# Altium schematic sheet LCD_SD.SchDoc(LCD_SD)
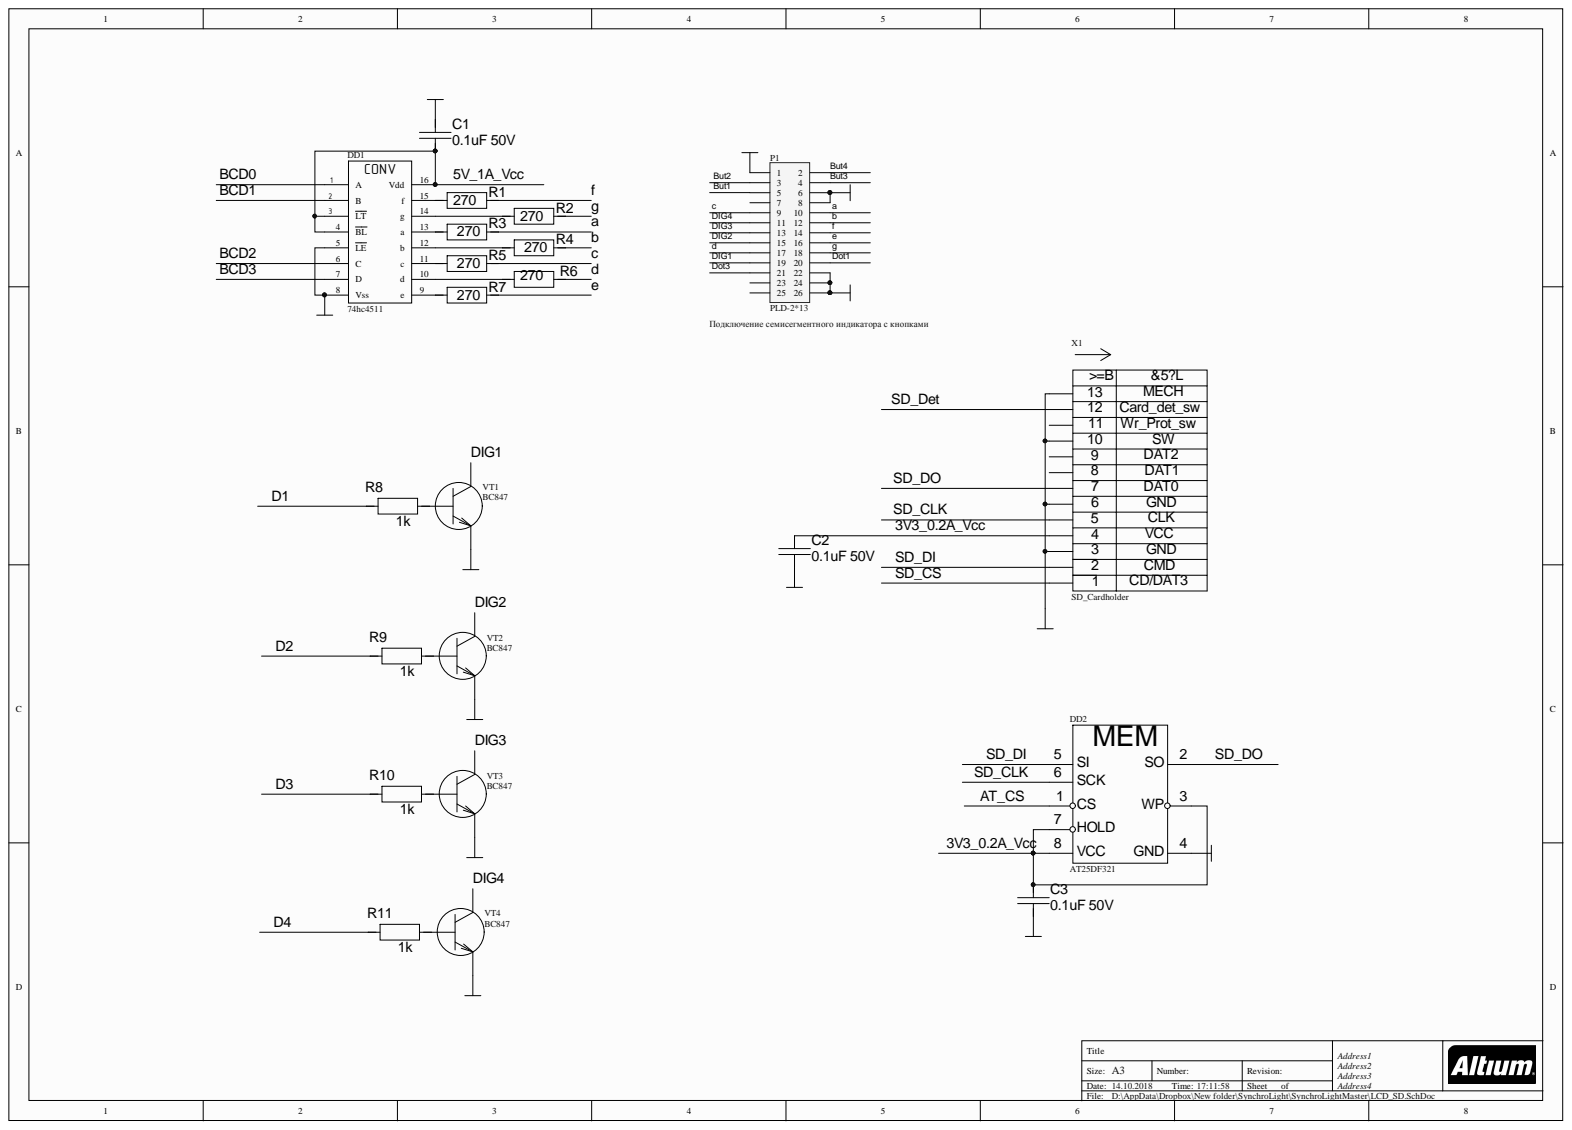
[diagram p0: LCD_SD.SchDoc]

## Parts
C1  0.1uF 50V  [0805_CAP]
C2  0.1uF 50V  [0805_CAP]
C3  0.1uF 50V  [0805_CAP]
DD1  74hc4511  [DIP16]
DD2  AT25DF321  [soic8-200]
P1  PLD-2*13  [HDR2X13]
R1  270  [0805_RES]
R2  270  [0805_RES]
R3  270  [0805_RES]
R4  270  [0805_RES]
R5  270  [0805_RES]
R6  270  [0805_RES]
R7  270  [0805_RES]
R8  1k  [0805_RES]
R9  1k  [0805_RES]
R10  1k  [0805_RES]
R11  1k  [0805_RES]
VT1  BC847  [sot23-3]
VT2  BC847  [sot23-3]
VT3  BC847  [sot23-3]
VT4  BC847  [sot23-3]
X1  SD_Cardholder  [SDcardHolder]

## Nets
GND: C1.2 C2.2 C3.2 DD1.5(LE) DD1.8(Vss) DD2.4(GND) P1.1 P1.6 P1.8 P1.22 P1.24 P1.26 VT1.2 VT2.2 VT3.2 VT4.2 X1.3 X1.6 X1.10 X1.13
3V3_0.2A_Vcc: C2.1 C3.1 DD2.3(WP) DD2.7(HOLD) DD2.8(VCC) X1.4
5V_1A_Vcc: C1.1 DD1.3(LT) DD1.4(BL) DD1.16(Vdd)
a: P1.10 R3.1
AT_CS: DD2.1(CS)
b: P1.12 R4.1
BCD0: DD1.1(A)
BCD1: DD1.2(B)
BCD2: DD1.6(C)
BCD3: DD1.7(D)
But1: P1.5
But2: P1.3
But3: P1.4
But4: P1.2
c: P1.9 R5.1
d: P1.17 R6.1
D1: R8.2
D2: R9.2
D3: R10.2
D4: R11.2
DIG1: P1.19 VT1.3
DIG2: P1.15 VT2.3
DIG3: P1.13 VT3.3
DIG4: P1.11 VT4.3
Dot1: P1.20
Dot3: P1.21
e: P1.16 R7.1
f: P1.14 R1.1
g: P1.18 R2.1
SD_CLK: DD2.6(SCK) X1.5
SD_CS: X1.1
SD_Det: X1.12
SD_DI: DD2.5(SI) X1.2
SD_DO: DD2.2(SO) X1.7
NetDD1_9: DD1.9(e) R7.2
NetDD1_10: DD1.10(d) R6.2
NetDD1_11: DD1.11(c) R5.2
NetDD1_12: DD1.12(b) R4.2
NetDD1_13: DD1.13(a) R3.2
NetDD1_14: DD1.14(g) R2.2
NetDD1_15: DD1.15(f) R1.2
NetR8_1: R8.1 VT1.1
NetR9_1: R9.1 VT2.1
NetR10_1: R10.1 VT3.1
NetR11_1: R11.1 VT4.1

Not connected: P1 7 23 25; X1 8 9 11

## Library data of the parts
C1-C3 = Cap_np: Value: 0.1uF 50V; Component Kind: Standard; Library Name: Integrated_Library_tikhovikt.IntLib
DD1 = CD4511: Value: 74hc4511; Component Kind: Standard; Library Name: Integrated_Library_tikhovikt.IntLib
P1 = Header 13X2: Header, 13-Pin, Dual row; Destiny: Подключение семисегментного индикатора с кнопками; Value: PLD-2*13; Signal Integrity: Connector; Library Name: Miscellaneous Connectors.IntLib; Component Kind: Standard
R1-R11 = Res: Component Kind: Standard; Library Name: Integrated_Library_tikhovikt.IntLib
  R1-R7: Value: 270
  R8-R11: Value: 1k
VT1-VT4 = transistor_npn: Value: BC847; Component Kind: Standard; Library Name: Integrated_Library_tikhovikt.IntLib
DD2 = AT25DF321: Value: AT25DF321; Component Kind: Standard; Library Name: Integrated_Library_tikhovikt.IntLib
X1 = SD_Cardholder: Value: SD_Cardholder; Component Kind: Standard; Library Name: Integrated_Library_tikhovikt.IntLib
